# Altium schematic sheet LoRaSnow.SchDoc
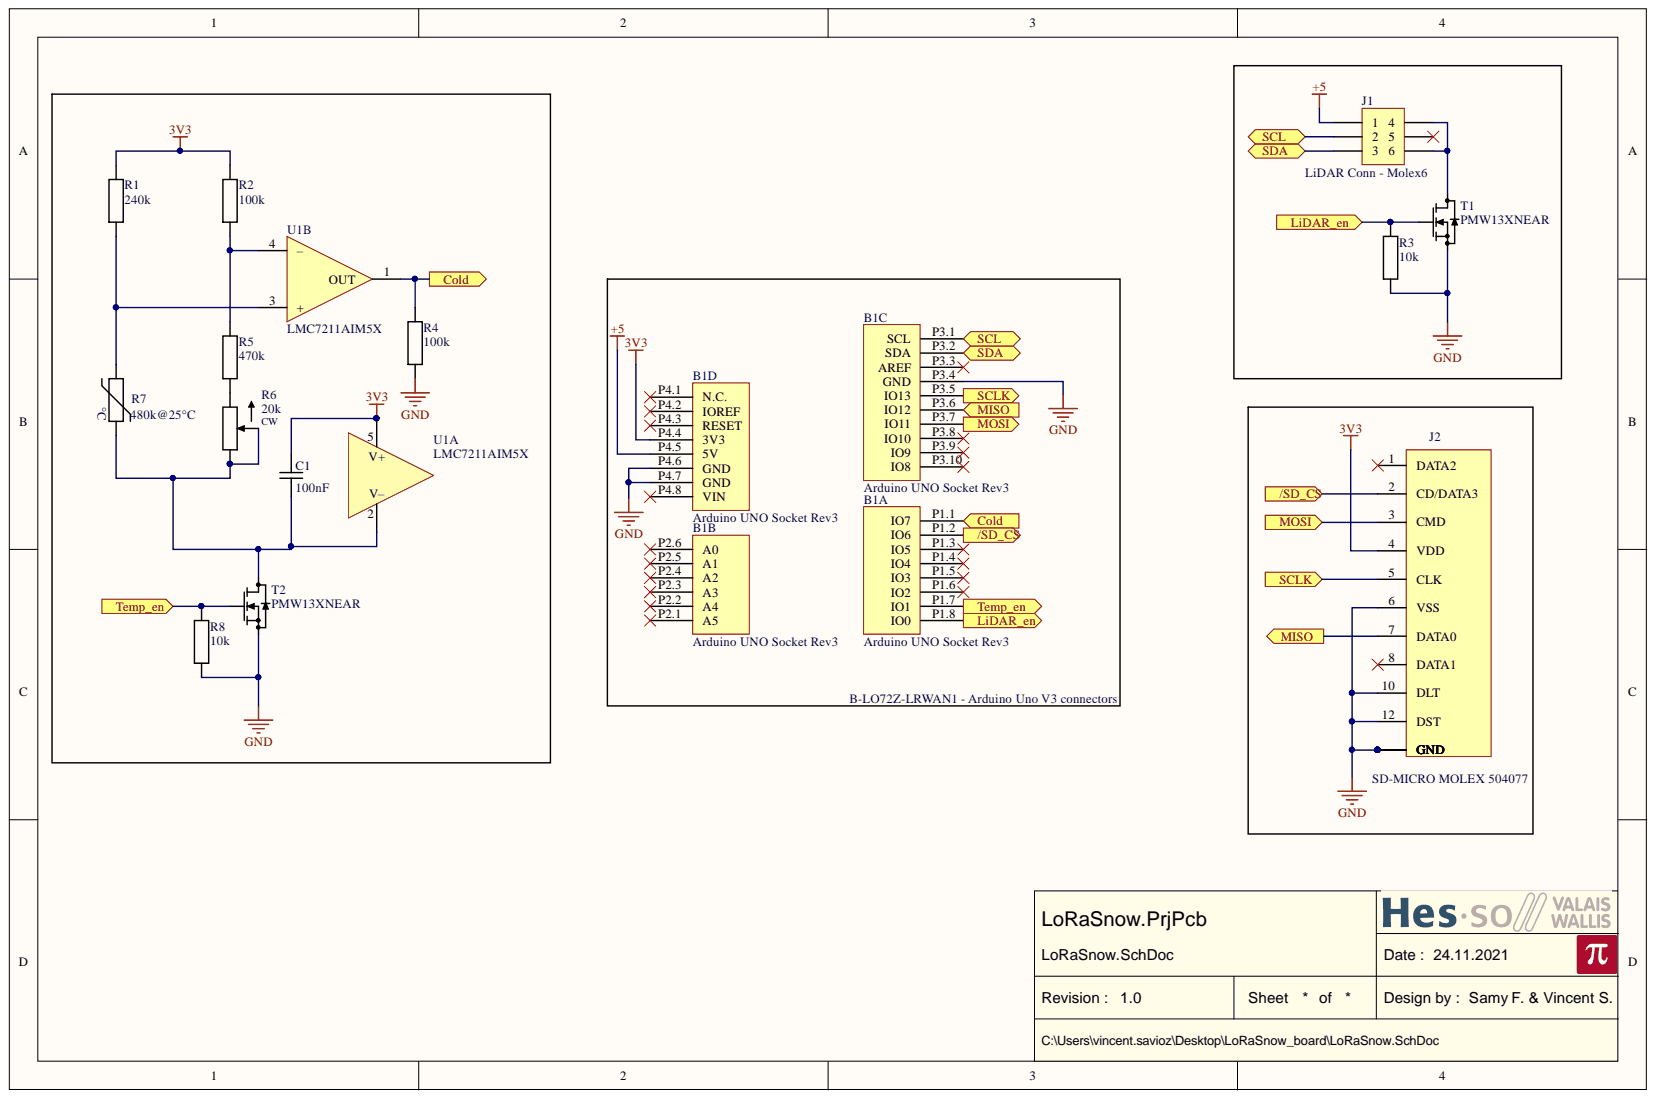
[diagram p0: LoRaSnow.SchDoc]

## Parts
B1  Arduino UNO Socket Rev3  [Arduino Uno Socket Rev3]  (parts A,B,C,D)
C1  100nF  [CAPACITOR 0510 REFLOW]
J1  LiDAR Conn - Molex6  [MOLEX 43045-0600]
J2  SD-MICRO MOLEX 504077  [SD MICRO MOLEX 504077]
R1  240k  [RESISTOR 1608 REFLOW]
R2  100k  [RESISTOR 1608 REFLOW]
R3  10k  [RESISTOR 1608 REFLOW]
R4  100k  [RESISTOR 1608 REFLOW]
R5  470k  [RESISTOR 1608 REFLOW]
R6  20k  [Trimmer BOURNS 3266W]
R7  480k@25°C  [RESISTOR 1608 REFLOW]
R8  10k  [RESISTOR 1608 REFLOW]
T1  PMW13XNEAR  [SOT23 P0.95 C1.6x3.0 H1.3]
T2  PMW13XNEAR  [SOT23 P0.95 C1.6x3.0 H1.3]
U1  LMC7211AIM5X  [SOT23-5 P0.95 C1.6x3.0 H1.3]  (parts A,B)

## Ports
/SD_CS, Cold, LiDAR_en, MISO, MOSI, SCL, SCLK, SDA, Temp_en

## Nets
3V3: B1.P4.4(3V3) C1.2 J2.4(VDD) R1.2 R2.2 U1.5(V+)
+5: B1.P4.5(5V) J1.1
GND: B1.P3.4(GND) B1.P4.6(GND) B1.P4.7(GND) J2.6(VSS) J2.9(GND) J2.10(DLT) J2.11 J2.12(DST) J2.13 J2.14 J2.15 J2.16 R3.1 R4.1 R8.1 T1.2 T2.2
/SD_CS: B1.P1.2(IO6) J2.2(CD/DATA3) ; port /SD_CS
Cold: B1.P1.1(IO7) R4.2 U1.1(OUT) ; port Cold
LiDAR_en: B1.P1.8(IO0) R3.2 T1.1 ; port LiDAR_en
MISO: B1.P3.6(IO12) J2.7(DATA0) ; port MISO
MOSI: B1.P3.7(IO11) J2.3(CMD) ; port MOSI
SCL: B1.P3.1(SCL) J1.2 ; port SCL
SCLK: B1.P3.5(IO13) J2.5(CLK) ; port SCLK
SDA: B1.P3.2(SDA) J1.3 ; port SDA
Temp_en: B1.P1.7(IO1) R8.2 T2.1 ; port Temp_en
NetC1_1: C1.1 R6.1 R6.2 R7.2 T2.3 U1.2(V–)
NetJ1_4: J1.4 J1.6 T1.3
NetR1_1: R1.1 R7.1 U1.3(+)
NetR2_1: R2.1 R5.2 U1.4(–)
NetR5_1: R5.1 R6.3

Not connected: B1 P1.3(IO5) P1.4(IO4) P1.5(IO3) P1.6(IO2) P2.1(A5) P2.2(A4) P2.3(A3) P2.4(A2) P2.5(A1) P2.6(A0) P3.3(AREF) P3.8(IO10) P3.9(IO9) P3.10(IO8) P4.1(N.C.) P4.2(IOREF) P4.3(RESET) P4.8(VIN); J1 5; J2 1(DATA2) 8(DATA1)

## Library data of the parts
B1 = Arduino UNO Socket Rev3: Microcontroller board based on the ATmega328P Rev3; Component Kind: Standard; Library Name: DevBoard.IntLib; Value: Arduino UNO Socket Rev3
C1 = Capacitor: Component Kind: Standard; Description: Capacitor; Library Name: Capacitor.IntLib; Value: 100nF
J1 = MOLEX 43045-0600: Micro-Fit 3.0 Right-Angle Header, 3.00mm Pitch, Dual Row, 6 Circuits; Component Kind: Standard; Library Name: Connector.IntLib
J2 = SD-MICRO MOLEX 504077: SD Card Micro; Component Kind: Standard; Library Name: Connector.IntLib
R1-R5 R8 = Resistor: Component Kind: Standard; Description: Resistor; Library Name: Resistor.IntLib
  R1: Value: 240k
  R2 R4: Value: 100k
  R3 R8: Value: 10k
  R5: Value: 470k
R6 = Trimmer: Component Kind: Standard; CW: CW; Description: Trimmer; Library Name: Resistor.IntLib; Value: 20k
R7 = Thermal Resistor: Component Kind: Standard; Description: Thermal Resistor; Library Name: Resistor.IntLib; Value: 480k@25°C
T1 T2 = MOS-N SOT23 G-S-D: Generic MOS-N; Component Kind: Standard; Library Name: Transistor.IntLib; Value: PMW13XNEAR
U1 = COMPARATOR SINGLE SOT23-5: Generic Single Comparator 5pins 1OUT/2-V/3+IN/4-IN/5+V; Component Kind: Standard; Library Name: Comparator.IntLib; Value: LMC7211AIM5X
